# Altium schematic sheet 5.04.51805A02_RKSch.SchDoc(5.04.51805A02_RKSch)
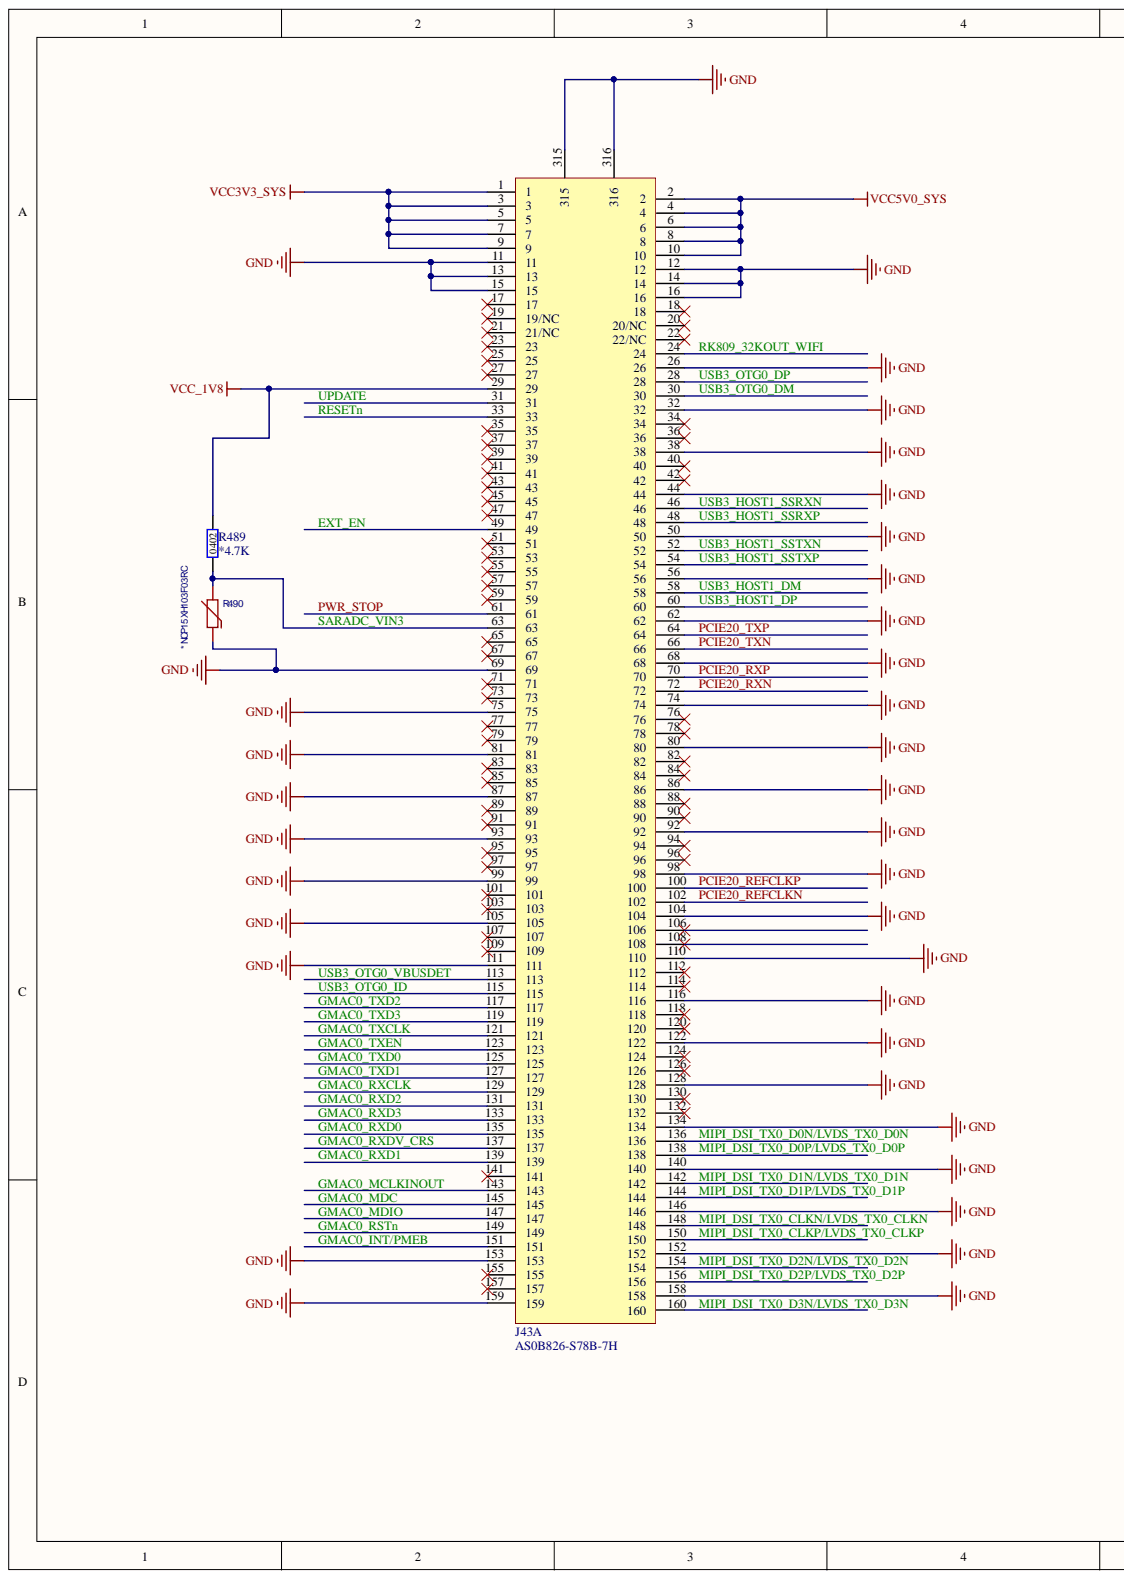
[diagram p0: 5.04.51805A02_RKSch.SchDoc, left]
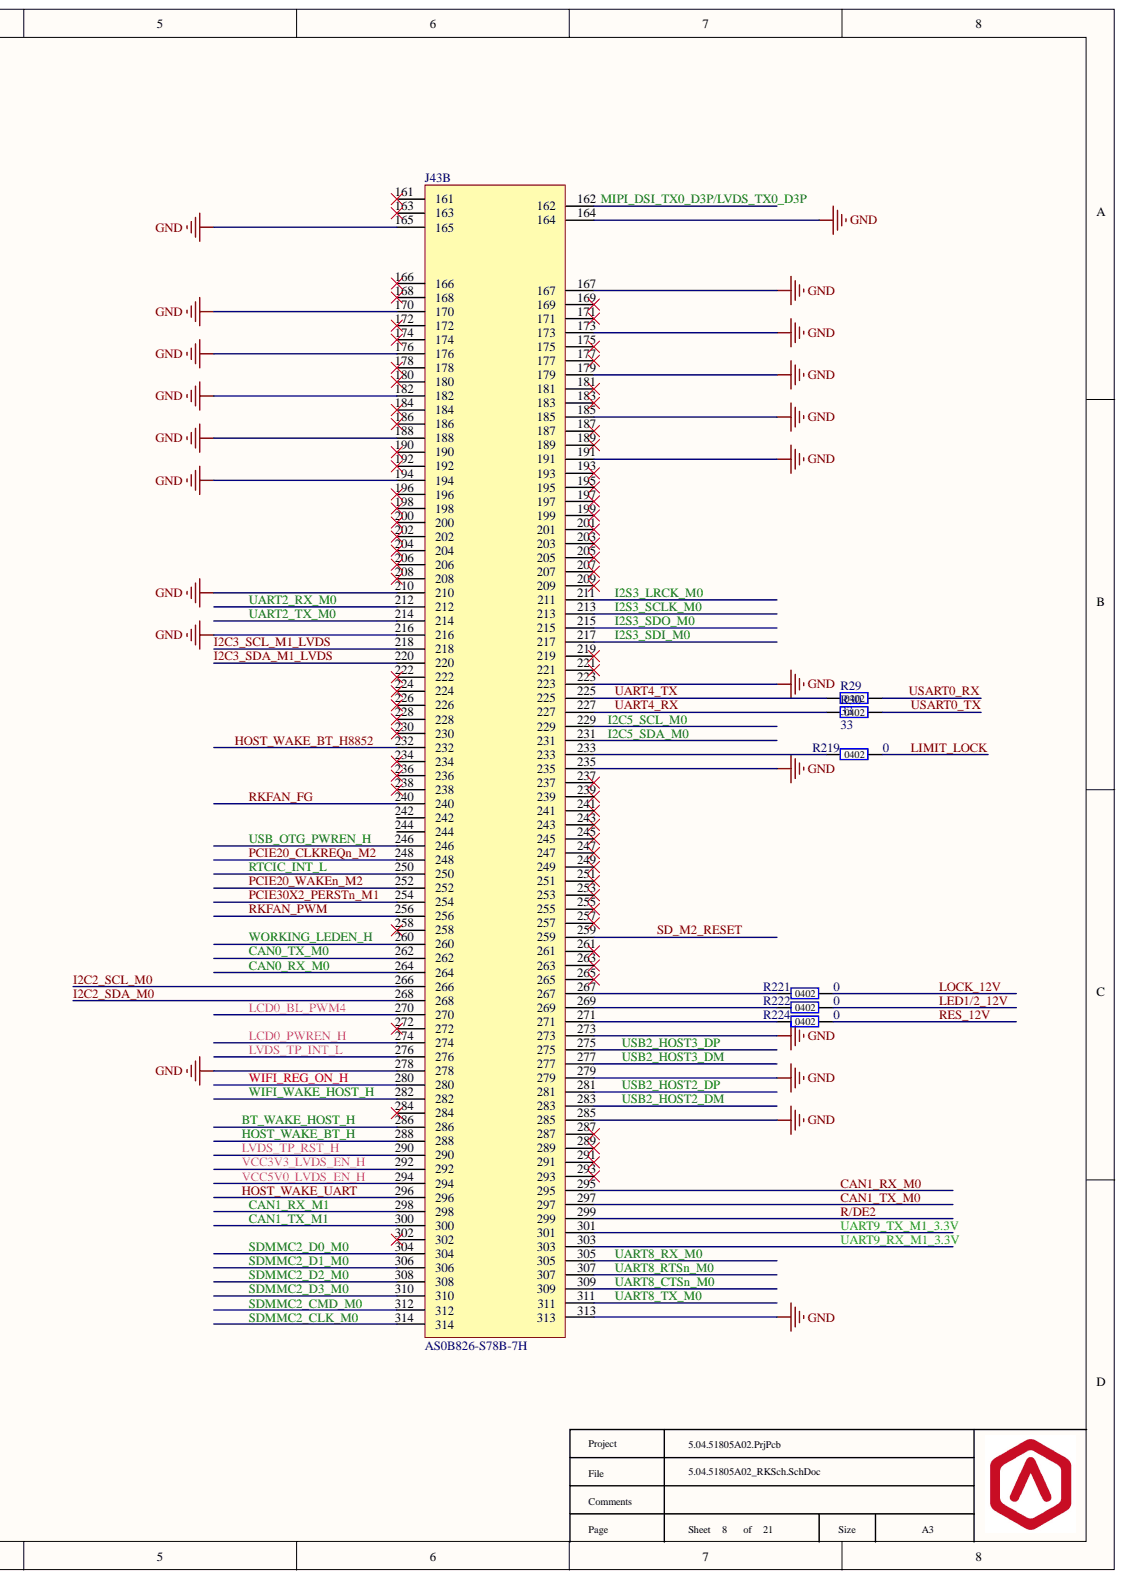
[diagram p1: 5.04.51805A02_RKSch.SchDoc, right]

## Parts
J43  AS0B826-S78B-7H  [PCIE_MXM3.0_314P_AS0B826-S78B-7H - duplicate]  (parts A,B)
R29  33  [R0402]
R30  33  [R0402]
R219  0  [R0402]
R221  0  [R0402]
R222  0  [R0402]
R224  0  [R0402]
R489  *4.7K  [R0402]
R490  *NCP15XH103F03RC  [R0402]

## Nets
GND: J43.11 J43.12 J43.13 J43.14 J43.15 J43.16 J43.26 J43.32 J43.38 J43.44 J43.50 J43.56 J43.62 J43.68 J43.69 J43.74 J43.75 J43.80 J43.81 J43.86 J43.87 J43.92 J43.93 J43.98 J43.99 J43.104 J43.105 J43.110 J43.111 J43.116 J43.122 J43.128 J43.134 J43.140 J43.146 J43.152 J43.153 J43.158 J43.159 J43.164 J43.165 J43.167 J43.170 J43.173 J43.176 J43.179 J43.182 J43.185 J43.188 J43.191 J43.194 J43.210 J43.216 J43.223 J43.235 J43.273 J43.278 J43.279 J43.285 J43.313 J43.315 J43.316 R490.1
VCC3V3_SYS: J43.1 J43.3 J43.5 J43.7 J43.9
VCC5V0_SYS: J43.2 J43.4 J43.6 J43.8 J43.10
VCC_1V8: J43.29 R489.2
BT_WAKE_HOST_H: J43.286
CAN0_RX_M0: J43.264
CAN0_TX_M0: J43.262
CAN1_RX_M0: J43.295
CAN1_RX_M1: J43.298
CAN1_TX_M0: J43.297
CAN1_TX_M1: J43.300
EXT_EN: J43.49
GMAC0_INT/PMEB: J43.151
GMAC0_MCLKINOUT: J43.143
GMAC0_MDC: J43.145
GMAC0_MDIO: J43.147
GMAC0_RSTN: J43.149
GMAC0_RXCLK: J43.129
GMAC0_RXD0: J43.135
GMAC0_RXD1: J43.139
GMAC0_RXD2: J43.131
GMAC0_RXD3: J43.133
GMAC0_RXDV_CRS: J43.137
GMAC0_TXCLK: J43.121
GMAC0_TXD0: J43.125
GMAC0_TXD1: J43.127
GMAC0_TXD2: J43.117
GMAC0_TXD3: J43.119
GMAC0_TXEN: J43.123
HOST_WAKE_BT_H: J43.288
HOST_WAKE_BT_H8852: J43.232
HOST_WAKE_UART: J43.296
I2C2_SCL_M0: J43.266
I2C2_SDA_M0: J43.268
I2C3_SCL_M1_LVDS: J43.218
I2C3_SDA_M1_LVDS: J43.220
I2C5_SCL_M0: J43.229
I2C5_SDA_M0: J43.231
I2S3_LRCK_M0: J43.211
I2S3_SCLK_M0: J43.213
I2S3_SDI_M0: J43.217
I2S3_SDO_M0: J43.215
LCD0_BL_PWM4: J43.270
LCD0_PWREN_H: J43.274
LED1/2_12V: R222.2
LIMIT_LOCK: R219.2
LOCK_12V: R221.2
LVDS_TP_INT_L: J43.276
LVDS_TP_RST_H: J43.290
MIPI_DSI_TX0_CLKN/LVDS_TX0_CLKN: J43.148
MIPI_DSI_TX0_CLKP/LVDS_TX0_CLKP: J43.150
MIPI_DSI_TX0_D0N/LVDS_TX0_D0N: J43.136
MIPI_DSI_TX0_D0P/LVDS_TX0_D0P: J43.138
MIPI_DSI_TX0_D1N/LVDS_TX0_D1N: J43.142
MIPI_DSI_TX0_D1P/LVDS_TX0_D1P: J43.144
MIPI_DSI_TX0_D2N/LVDS_TX0_D2N: J43.154
MIPI_DSI_TX0_D2P/LVDS_TX0_D2P: J43.156
MIPI_DSI_TX0_D3N/LVDS_TX0_D3N: J43.160
MIPI_DSI_TX0_D3P/LVDS_TX0_D3P: J43.162
PCIE20_CLKREQN_M2: J43.248
PCIE20_REFCLKN: J43.102
PCIE20_REFCLKP: J43.100
PCIE20_RXN: J43.72
PCIE20_RXP: J43.70
PCIE20_TXN: J43.66
PCIE20_TXP: J43.64
PCIE20_WAKEN_M2: J43.252
PCIE30X2_PERSTN_M1: J43.254
PWR_STOP: J43.61
R/DE2: J43.299
RES_12V: R224.2
RESETN: J43.33
RK809_32KOUT_WIFI: J43.24
RKFAN_FG: J43.240
RKFAN_PWM: J43.256
RTCIC_INT_L: J43.250
SARADC_VIN3: J43.63 R489.1 R490.2
SD_M2_RESET: J43.259
SDMMC2_CLK_M0: J43.314
SDMMC2_CMD_M0: J43.312
SDMMC2_D0_M0: J43.304
SDMMC2_D1_M0: J43.306
SDMMC2_D2_M0: J43.308
SDMMC2_D3_M0: J43.310
UART2_RX_M0: J43.212
UART2_TX_M0: J43.214
UART4_RX: J43.227 R30.1
UART4_TX: J43.225 R29.1
UART8_CTSN_M0: J43.309
UART8_RTSN_M0: J43.307
UART8_RX_M0: J43.305
UART8_TX_M0: J43.311
UART9_RX_M1_3.3V: J43.303
UART9_TX_M1_3.3V: J43.301
UPDATE: J43.31
USART0_RX: R29.2
USART0_TX: R30.2
USB2_HOST2_DM: J43.283
USB2_HOST2_DP: J43.281
USB2_HOST3_DM: J43.277
USB2_HOST3_DP: J43.275
USB3_HOST1_DM: J43.58
USB3_HOST1_DP: J43.60
USB3_HOST1_SSRXN: J43.46
USB3_HOST1_SSRXP: J43.48
USB3_HOST1_SSTXN: J43.52
USB3_HOST1_SSTXP: J43.54
USB3_OTG0_DM: J43.30
USB3_OTG0_DP: J43.28
USB3_OTG0_ID: J43.115
USB3_OTG0_VBUSDET: J43.113
USB_OTG_PWREN_H: J43.246
VCC3V3_LVDS_EN_H: J43.292
VCC5V0_LVDS_EN_H: J43.294
WIFI_REG_ON_H: J43.280
WIFI_WAKE_HOST_H: J43.282
WORKING_LEDEN_H: J43.260
NetJ43_233: J43.233 R219.1
NetJ43_267: J43.267 R221.1
NetJ43_269: J43.269 R222.1
NetJ43_271: J43.271 R224.1

Not connected: J43 17 18 19(19/NC) 20(20/NC) 21(21/NC) 22(22/NC) 23 25 27 34 35 36 37 39 40 41 42 43 45 47 51 53 55 57 59 65 67 71 73 76 77 78 79 82 83 84 85 88 89 90 91 94 95 96 97 101 103 106 107 108 109 112 114 118 120 124 126 130 132 141 155 157 161 163 166 168 169 171 172 174 175 177 178 180 181 183 184 186 187 189 190 192 193 195 196 197 198 199 200 201 202 203 204 205 206 207 208 209 219 221 222 224 226 228 230 234 236 237 238 239 241 242 243 244 245 247 249 251 253 255 257 258 261 263 265 272 284 287 289 291 293 302

## Library data of the parts
J43 = AS0B826-S78B-7H: PCIE MXM3.0显卡接口; ManufacturerPartNumber1: 富士康; Component Kind: Standard; Manufacturer1: AS0B826-S78B-7H; Library Name: QQQ.IntLib
R29 R30 = R0402_33: Resistor 33ohm,1%,1/16W,R0402; Manufacturer1: Yageo; ManufacturerPartNumber1: RC0402FR-0733RL; Code: 5001033R; Component Kind: Standard; Library Name: Raise3D_Resistor.IntLib
R219 R221 R222 R224 = R0402_0: Resistor 0ohm,1%,1/16W,R0402; Manufacturer1: Yageo; ManufacturerPartNumber1: RC0402FR-070RL; Code: 5001000R; Component Kind: Standard; Library Name: Raise3D_Resistor.IntLib
R489 = R0402_4.7K: Resistor 4.7Kohm,5%,1/16W,R0402; Manufacturer1: Yageo; ManufacturerPartNumber1: RC0402JR-074K7L; Code: 501104K7; Component Kind: Standard; Manufacturer2: Samsung; ManufacturerPartNumber2: RCS1005J472CS; Library Name: Raise3D_Resistor.IntLib
R490 = NCP15XH103F03RC: 10kΩ 电阻精度:±1% 3380K; Manufacturer1: muRata(村田); Component Kind: Standard; ManufacturerPartNumber1: NCP15XH103F03RC; Library Name: QQQ.IntLib
